# Altium schematic sheet 即热式热水器控制系统原理图_主板(V1.9).SchDoc
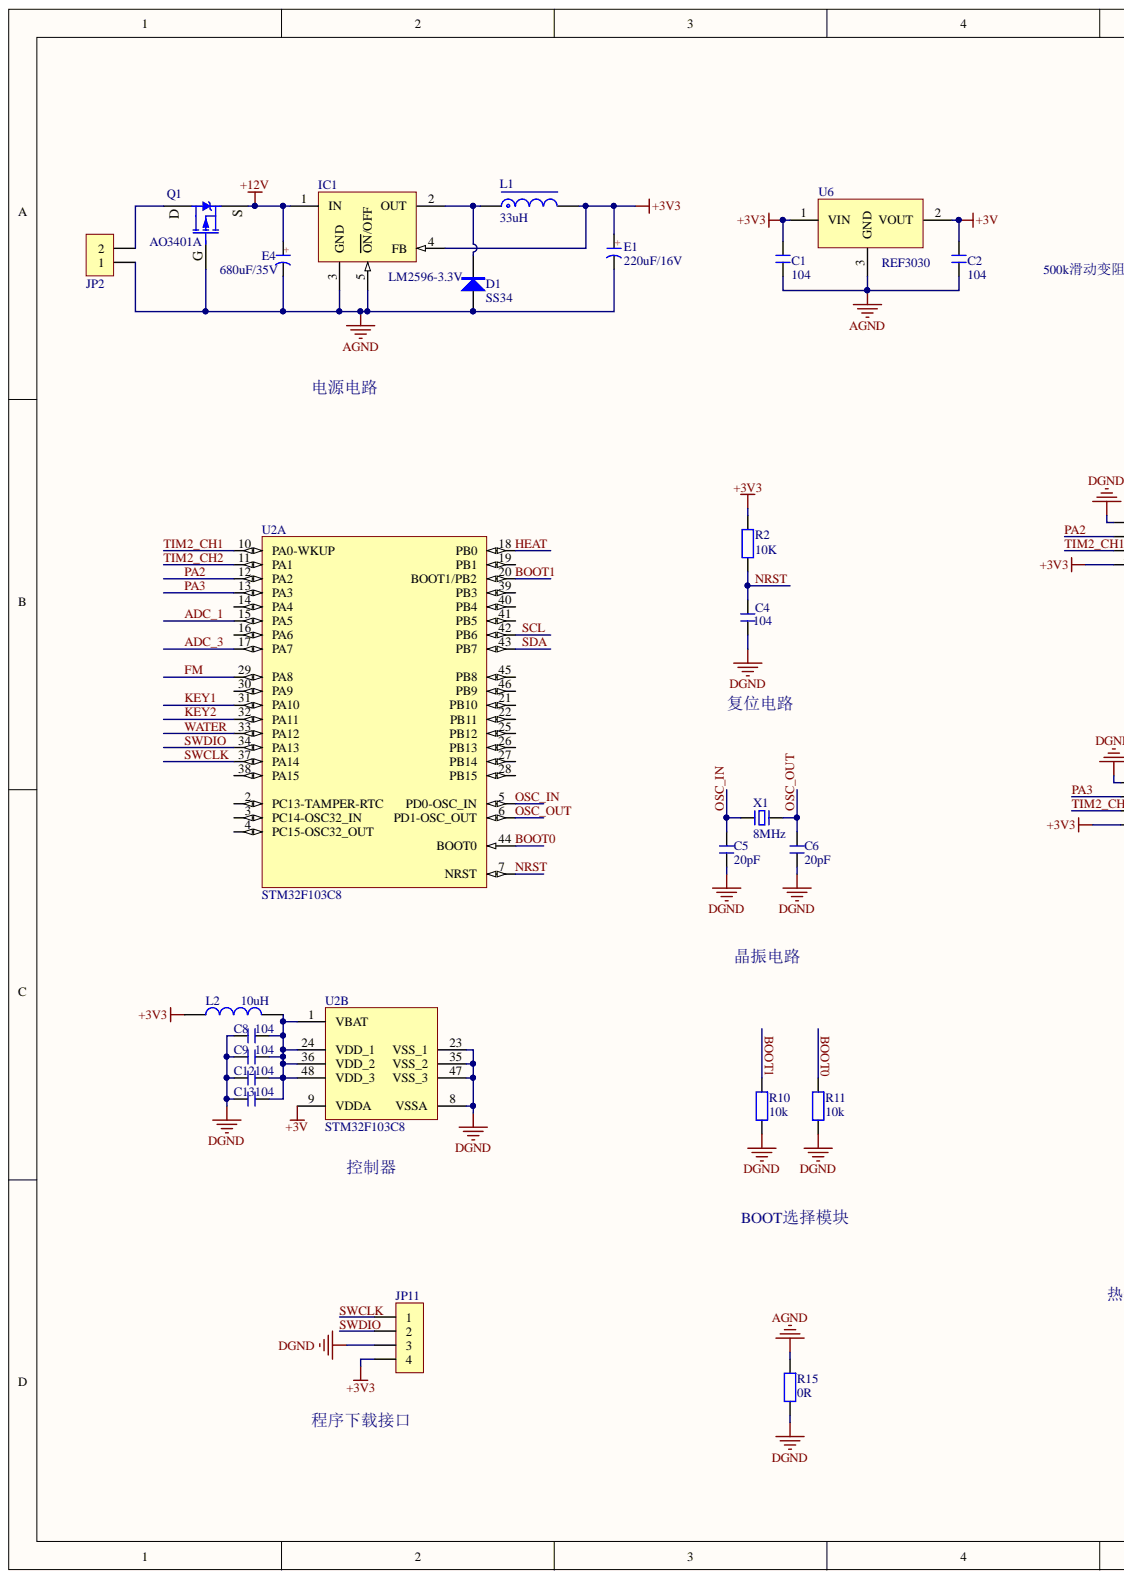
[diagram p0: 即热式热水器控制系统原理图_主板(V1.9).SchDoc, left]
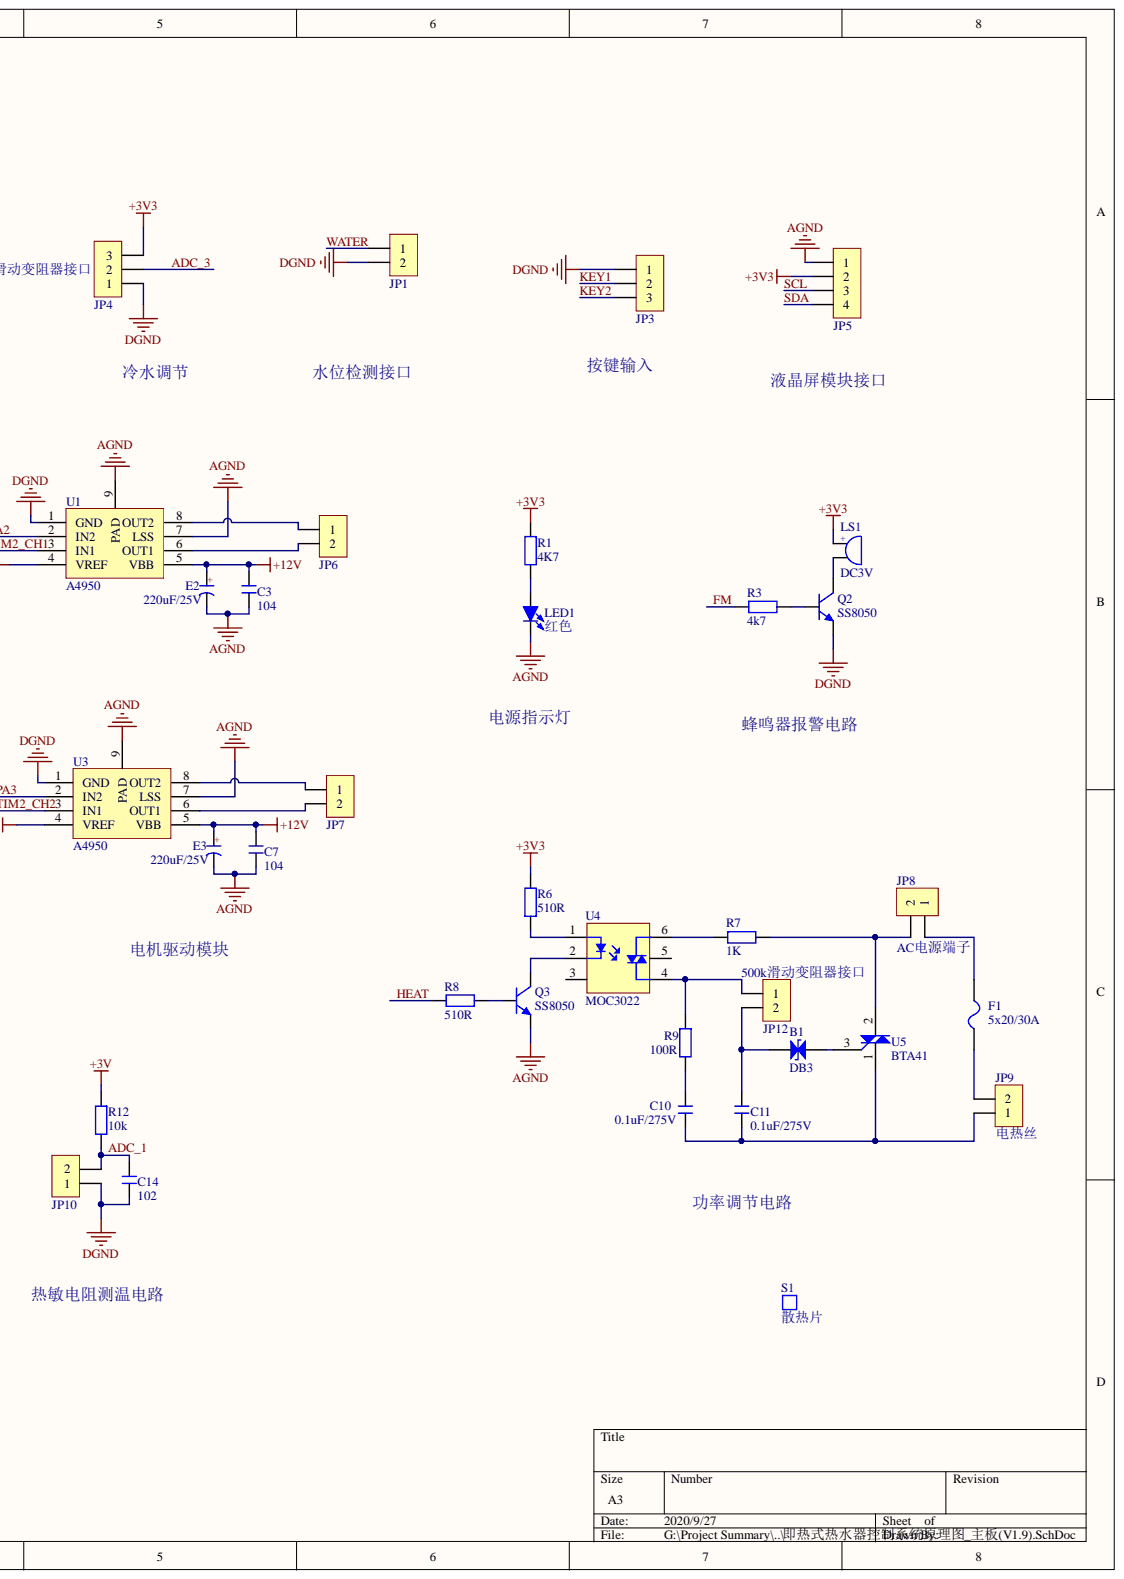
[diagram p1: 即热式热水器控制系统原理图_主板(V1.9).SchDoc, right]

## Parts
B1  DB3  [DO-35]
C1  104  [C 0603_M]
C2  104  [C 0603_M]
C3  104  [C 0603_M]
C4  104  [C 0603_M]
C5  20pF  [C 0603_M]
C6  20pF  [C 0603_M]
C7  104  [C 0603_M]
C8  104  [C 0603_M]
C9  104  [C 0603_M]
C10  0.1uF/275V  [CBB 10*12*8]
C11  0.1uF/275V  [CBB 10*12*8]
C12  104  [C 0603_M]  (value not drawn)
C13  104  [C 0603_M]  (value not drawn)
C14  102  [C 0603_M]
D1  SS34  [SMA]
E1  220uF/16V  [CAP 2.5*6.3*11.2]
E2  220uF/25V  [CAP 2.5*6.3*11.2 - BK]
E3  220uF/25V  [CAP 2.5*6.3*11.2 - BK]
E4  680uF/35V  [CAP 5.0*10*16]
F1  5x20/30A  [FU BLX-A]
IC1  LM2596-3.3V  [TO263-5A]
JP1  HDR-1X2  [PH2.0-LS-2P]  (value not drawn)
JP2  HDR-1X2  [VH3.96-LI-2P]  (value not drawn)
JP3  HDR-1X3  [MX 1.25-LS-3P]  (value not drawn)
JP4  HDR-1X3  [XH2.54-LI-3P]  (value not drawn)
JP5  HDR-1X4  [MX 1.25-LS-4P]  (value not drawn)
JP6  HDR-1X2  [XH2.54-LI-2P]  (value not drawn)
JP7  HDR-1X2  [XH2.54-LI-2P]  (value not drawn)
JP8  AC电源端子  [KF2EDGK5.08-LI-2P]
JP9  电热丝  [KF2EDGK5.08-LI-2P]
JP10  HDR-1X2  [XH2.54-LI-2P]  (value not drawn)
JP11  HDR-1X4  [MX 1.25-LS-4P]  (value not drawn)
JP12  HDR-1X2  [VH3.96-LI-2P]  (value not drawn)
L1  33uH  [CDRH74]
L2  10uH  [L 0805]
LED1  红色  [LED 0805R]
LS1  DC3V  [BEEP 5x9x5.5]
Q1  AO3401A  [SOT23-3M]
Q2  SS8050  [SOT23-3N]
Q3  SS8050  [SOT23-3N]
R1  4K7  [R 0603_M]
R2  10K  [R 0603_M]
R3  4k7  [R 0603_M]
R6  510R  [R 0603_M]
R7  1K  [RS 1W]
R8  510R  [R 0603_M]
R9  100R  [RS 1W]
R10  10k  [R 0603_M]
R11  10k  [R 0603_M]
R12  10k  [R 0603_M]
R15  0R  [R 0805_M]
S1  散热片  [SRP12-34-25-P]
U1  A4950  [SOIC_8_EP]
U2  STM32F103C8  [LQFP48 7X7_N]  (parts A,B)
U3  A4950  [SOIC_8_EP]
U4  MOC3022  [DIP6-300]
U5  BTA41  [TOP-3]
U6  REF3030  [SOT23-3N]
X1  8MHz  [OSC 5032-2P]

## Nets
+3V: C2.1 R12.2 U2.9(VDDA) U6.2
+3V3: C1.1 E1.1 IC1.4(FB) JP4.3 JP5.2 JP11.4 L1.2 L2.1 LS1.1 R1.2 R2.2 R6.1 U1.4(VREF) U3.4(VREF) U6.1
+12V: C3.1 C7.1 E2.1 E3.1 E4.1 IC1.1(IN) Q1.2 U1.5(VBB) U3.5(VBB)
AGND: C1.2 C2.2 C3.2 C7.2 D1.1 E1.2 E2.2 E3.2 E4.2 IC1.3(GND) IC1.5(ON/OFF) JP2.1 JP5.1 LED1.2 Q1.1 Q3.2 R15.2 U1.7(LSS) U1.9(PAD) U3.7(LSS) U3.9(PAD) U6.3
DGND: C4.1 C5.1 C6.1 C8.2 C9.2 C12.2 C13.2 C14.2 JP1.2 JP3.1 JP4.1 JP10.1 JP11.3 Q2.2 R10.1 R11.1 R15.1 U1.1(GND) U2.8(VSSA) U2.23(VSS_1) U2.35(VSS_2) U2.47(VSS_3) U3.1(GND)
ADC_1: C14.1 JP10.2 R12.1 U2.15(PA5)
ADC_3: JP4.2 U2.17(PA7)
BOOT0: R11.2 U2.44(BOOT0)
BOOT1: R10.2 U2.20(BOOT1/PB2)
FM: R3.2 U2.29(PA8)
HEAT: R8.1 U2.18(PB0)
KEY1: JP3.2 U2.31(PA10)
KEY2: JP3.3 U2.32(PA11)
NRST: C4.2 R2.1 U2.7(NRST)
OSC_IN: C5.2 U2.5(PD0-OSC_IN) X1.1
OSC_OUT: C6.2 U2.6(PD1-OSC_OUT) X1.2
PA2: U1.2(IN2) U2.12(PA2)
PA3: U2.13(PA3) U3.2(IN2)
SCL: JP5.3 U2.42(PB6)
SDA: JP5.4 U2.43(PB7)
SWCLK: JP11.1 U2.37(PA14)
SWDIO: JP11.2 U2.34(PA13)
TIM2_CH1: U1.3(IN1) U2.10(PA0-WKUP)
TIM2_CH2: U2.11(PA1) U3.3(IN1)
WATER: JP1.1 U2.33(PA12)
NetB1_1: B1.1 C11.2 JP12.2
NetB1_2: B1.2 U5.3
NetC8_1: C8.1 C9.1 C12.1 C13.1 L2.2 U2.1(VBAT) U2.24(VDD_1) U2.36(VDD_2) U2.48(VDD_3)
NetC10_1: C10.1 C11.1 JP9.1 U5.1
NetC10_2: C10.2 R9.1
NetD1_2: D1.2 IC1.2(OUT) L1.1
NetF1_1: F1.1 JP8.1
NetF1_2: F1.2 JP9.2
NetJP2_2: JP2.2 Q1.3
NetJP6_1: JP6.1 U1.8(OUT2)
NetJP6_2: JP6.2 U1.6(OUT1)
NetJP7_1: JP7.1 U3.8(OUT2)
NetJP7_2: JP7.2 U3.6(OUT1)
NetJP8_2: JP8.2 R7.1 U5.2
NetJP12_1: JP12.1 R9.2 U4.4
NetLED1_1: LED1.1 R1.1
NetLS1_2: LS1.2 Q2.3
NetQ2_1: Q2.1 R3.1
NetQ3_1: Q3.1 R8.2
NetQ3_3: Q3.3 U4.2
NetR6_2: R6.2 U4.1
NetR7_2: R7.2 U4.6

Not connected: U2 2(PC13-TAMPER-RTC) 3(PC14-OSC32_IN) 4(PC15-OSC32_OUT) 14(PA4) 16(PA6) 19(PB1) 21(PB10) 22(PB11) 25(PB12) 26(PB13) 27(PB14) 28(PB15) 30(PA9) 38(PA15) 39(PB3) 40(PB4) 41(PB5) 45(PB8) 46(PB9); U4 3 5

## Library data of the parts
B1 = DB3: 双向触发二极管; Component Kind: Standard; Library Name: 8.1   - 二极管-整流桥.SchLib
C1-C9 C12-C14 = C: 无极性贴片电容; 版权归属: 源创客; BOM名称: 无极性贴片电容; Component Kind: Standard; Library Name: 3.1   - 电阻-电容-电感.SchLib; 学习资源: www.ooocke.com
C10 C11 = C-CBB: CBB电容; 版权归属: 源创客; BOM名称: CBB电容; Component Kind: Standard; Library Name: 3.1   - 电阻-电容-电感.SchLib; 学习资源: www.ooocke.com
D1 = SS34: 肖特基二极管; 属性: 40V/3A; 版权归属: 源创客; BOM名称: 肖特基二极管; Component Kind: Standard; Library Name: 8.1   - 二极管-整流桥.SchLib; 学习资源: www.ooocke.com
E1-E4 = C-CAP: 直插电解电容; 版权归属: 源创客; BOM名称: 直插电解电容; Component Kind: Standard; Library Name: 3.1   - 电阻-电容-电感.SchLib; 学习资源: www.ooocke.com
F1 = FU 5x20: 5*20保险丝; 版权归属: 源创客; BOM名称: 5*20保险丝; Component Kind: Standard; Library Name: 10.1 - 保险丝-晶振.SchLib; 学习资源: www.ooocke.com
IC1 = LM2596-3.3: DC降压芯片; BOM名称: DC稳压芯片; 版权归属: 源创客; Component Kind: Standard; Library Name: 1.3   - 电源类芯片.SchLib; 学习资源: www.ooocke.com
JP1 JP2 JP6-JP10 JP12 = Header 2: 2P接插件; 版权归属: 源创客; BOM名称: 2P接插件; Component Kind: Standard; 学习资源: www.ooocke.com
  JP1: Library Name: 4.4   - 接插件-脚距2.0.SchLib
  JP2 JP12: Library Name: 4.8   - 接插件-脚距3.96.SchLib
  JP6 JP7 JP10: Library Name: 4.5   - 接插件-脚距2.54.SchLib
  JP8 JP9: Library Name: 4.10 - 接插件-脚距5.08.SchLib
JP3 JP4 = Header 3: 3P接插件; 版权归属: 源创客; BOM名称: 3P接插件; Component Kind: Standard; 学习资源: www.ooocke.com
  JP3: Library Name: 4.2   - 接插件-脚距1.25.SchLib
  JP4: Library Name: 4.5   - 接插件-脚距2.54.SchLib
JP5 JP11 = Header 4: 4P接插件; 版权归属: 源创客; BOM名称: 4P接插件; Component Kind: Standard; Library Name: 4.2   - 接插件-脚距1.25.SchLib; 学习资源: www.ooocke.com
L1 = L-CDRH: 功率屏蔽电感; 版权归属: 源创客; BOM名称: 功率屏蔽电感; Component Kind: Standard; Library Name: 3.1   - 电阻-电容-电感.SchLib; 学习资源: www.ooocke.com
L2 = L: 小功率贴片电感; 版权归属: 源创客; BOM名称: 小功率贴片电感; Component Kind: Standard; Library Name: 3.1   - 电阻-电容-电感.SchLib; 学习资源: www.ooocke.com
LED1 = LED-SMD: 贴片LED; 版权归属: 源创客; BOM名称: 贴片LED; Component Kind: Standard; Library Name: 9.1   - LED.SchLib; 学习资源: www.ooocke.com
LS1 = BEEP: 蜂鸣器; 版权归属: 源创客; BOM名称: 蜂鸣器; Component Kind: Standard; Library Name: 15.1 - 未分类器件.SchLib; 学习资源: www.ooocke.com
Q1 = SI2301: P沟道场效应管; 版权归属: 源创客; BOM名称: P沟道场效应管; Component Kind: Standard; Library Name: 6.1   - 场效应管-可控硅.SchLib; 学习资源: www.ooocke.com
Q2 Q3 = 8050-SMD: 高频放大-NPN型; 属性: 40V 1.5A 1W 100MHZ; 版权归属: 源创客; BOM名称: 高频放大-NPN型; Component Kind: Standard; Library Name: 6.2   - 三极管.SchLib; 学习资源: www.ooocke.com
R1-R3 R6 R8 R10-R12 R15 = R: 贴片电阻; 版权归属: 源创客; BOM名称: 贴片电阻; Component Kind: Standard; Library Name: 3.1   - 电阻-电容-电感.SchLib; 学习资源: www.ooocke.com
R7 R9 = R-CRR: 直插色环电阻; BOM名称: 色环电阻; 版权归属: 源创客; Component Kind: Standard; Library Name: 3.1   - 电阻-电容-电感.SchLib; 学习资源: www.ooocke.com
S1 = S1: 版权归属: 源创客; BOM名称: 散热片; Component Kind: Standard; Description: 散热片; Library Name: 15.1 - 未分类器件.SchLib; 学习资源: www.ooocke.com
U1 U3 = A4950: 全桥DMOS; Component Kind: Standard; Library Name: 1.1   - IC芯片.SchLib
U2 = STM32F103C8_A: Flaah:64/RAM:20/IO:36; BOM名称: 32位STM单片机; 版权归属: 源创客; Component Kind: Standard; Library Name: 2.1   - STM32系列单片机.SchLib; 学习资源: www.ooocke.com
U4 = MOC3022: 光可控硅; 版权归属: 源创客; BOM名称: 光可控硅; Component Kind: Standard; Library Name: 6.1   - 场效应管-可控硅.SchLib; 学习资源: www.ooocke.com
U5 = BTA41: 双向可控硅; Component Kind: Standard; Library Name: 6.1   - 场效应管-可控硅.SchLib
U6 = REF30xx: 电压基准芯片; Component Kind: Standard; Library Name: 1.3   - 电源类芯片.SchLib
X1 = XTAL-2P: 2脚无源脚晶振; 版权归属: 源创客; BOM名称: 2脚无源脚晶振; Component Kind: Standard; Library Name: 10.1 - 保险丝-晶振.SchLib; 学习资源: www.ooocke.com
